# Altium schematic sheet dv_mcu.SchDoc(dv_mcu)
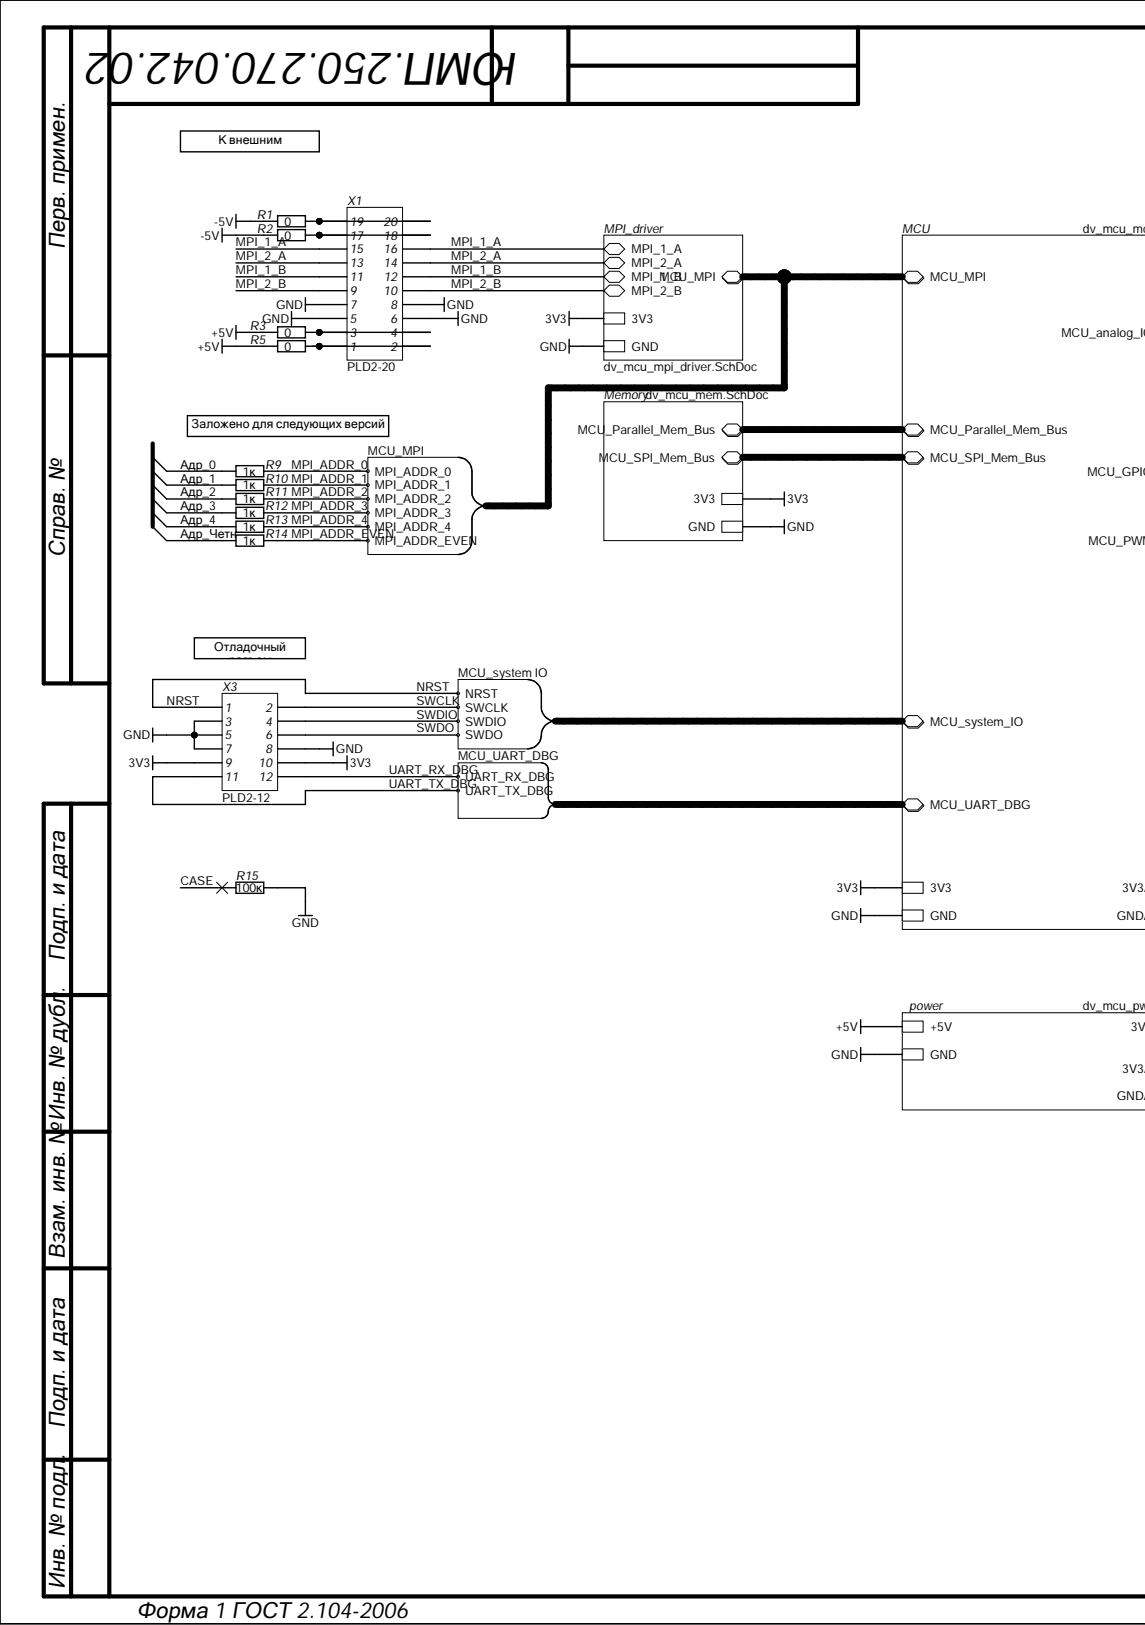
[diagram p0: dv_mcu.SchDoc, left]
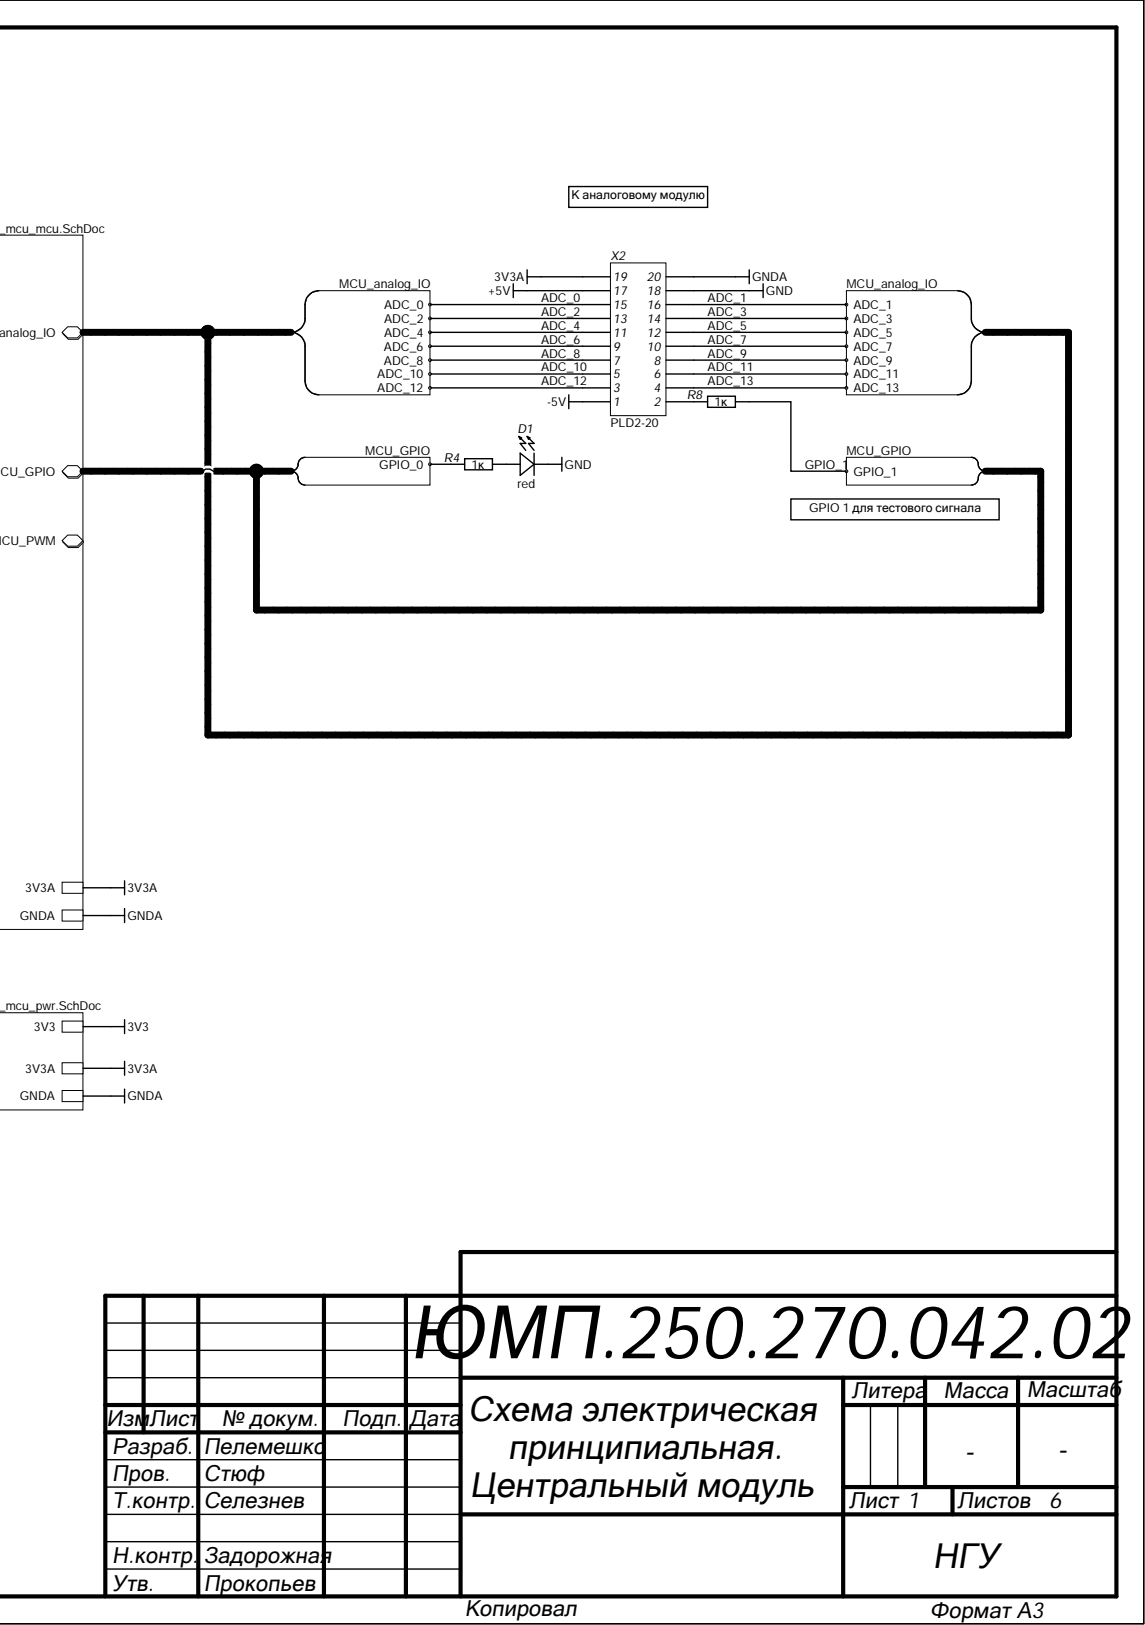
[diagram p1: dv_mcu.SchDoc, right]

## Parts
D1  value red  [LED-0805]  (hidden comment: LED)
R1  value 0  [SMD_0805]  (hidden comment: OSM R1-12)
R2  value 0  [SMD_0805]  (hidden comment: OSM R1-12)
R3  value 0  [SMD_0805]  (hidden comment: OSM R1-12)
R4  value 1к  [SMD_0805]  (hidden comment: OSM R1-12)
R5  value 0  [SMD_0805]  (hidden comment: OSM R1-12)
R8  value 1к  [SMD_0805]  (hidden comment: OSM R1-12)
R9  value 1к  [SMD_0805]  (hidden comment: OSM R1-12)
R10  value 1к  [SMD_0805]  (hidden comment: OSM R1-12)
R11  value 1к  [SMD_0805]  (hidden comment: OSM R1-12)
R12  value 1к  [SMD_0805]  (hidden comment: OSM R1-12)
R13  value 1к  [SMD_0805]  (hidden comment: OSM R1-12)
R14  value 1к  [SMD_0805]  (hidden comment: OSM R1-12)
R15  value 100к  [SMD_0805]  (hidden comment: OSM R1-12)
X1  Наименование: PLD2-20  [SD87340-20]  (hidden comment: Header 2X20)
X2  Наименование: PLD2-20  [SD87340-20]  (hidden comment: Header 2X20)
X3  Header 2X12  [PLD2-12]  (value not drawn)

## Nets
3V3: X3.9 X3.10
3V3A: X2.19
+5V: R3.1 R5.1 X2.17
-5V: R1.1 R2.1 X2.1
GND: D1.2 R15.2 X1.5 X1.6 X1.7 X1.8 X2.18 X3.3 X3.5 X3.7 X3.8
GNDA: X2.20
ADC_0: X2.15  [also labelled ADC_]
ADC_1: X2.16  [also labelled ADC_]
ADC_2: X2.13  [also labelled ADC_]
ADC_3: X2.14  [also labelled ADC_]
ADC_4: X2.11  [also labelled ADC_]
ADC_5: X2.12  [also labelled ADC_]
ADC_6: X2.9  [also labelled ADC_]
ADC_7: X2.10  [also labelled ADC_]
ADC_8: X2.7  [also labelled ADC_]
ADC_9: X2.8  [also labelled ADC_]
ADC_10: X2.5  [also labelled ADC_]
ADC_11: X2.6  [also labelled ADC_]
ADC_12: X2.3  [also labelled ADC_]
ADC_13: X2.4  [also labelled ADC_]
CASE: R15.1
GPIO_1: R8.2
MPI_1_A: X1.15 X1.16
MPI_1_B: X1.11 X1.12
MPI_2_A: X1.13 X1.14
MPI_2_B: X1.9 X1.10
MPI_ADDR_0: R9.2
MPI_ADDR_1: R10.2
MPI_ADDR_2: R11.2
MPI_ADDR_3: R12.2
MPI_ADDR_4: R13.2
MPI_ADDR_EVEN: R14.2
NRST: X3.1
SWCLK: X3.2
SWDIO: X3.4
SWDO: X3.6
UART_RX_DBG: X3.12
UART_TX_DBG: X3.11
Адр_0: R9.1
Адр_1: R10.1
Адр_2: R11.1
Адр_3: R12.1
Адр_4: R13.1
Адр_Четн: R14.1
NetD1_1: D1.1 R4.2
NetR1_2: R1.2 X1.19 X1.20
NetR2_2: R2.2 X1.17 X1.18
NetR3_2: R3.2 X1.3 X1.4
NetR4_1: R4.1
NetR5_2: R5.2 X1.1 X1.2
NetR8_1: R8.1 X2.2
MCU_analog_IO.ADC_REF:
MCU_GPIO.GPIO_2:
MCU_GPIO.GPIO_3:
MCU_GPIO.GPIO_4:
MCU_GPIO.GPIO_5:
MCU_GPIO.GPIO_6:
MCU_GPIO.GPIO_7:
MCU_GPIO.STM_0:
MCU_GPIO.STM_1:
MCU_GPIO.STM_2:
MCU_GPIO.STM_3:
MCU_GPIO.STM_4:
MCU_GPIO.STM_5:
MCU_GPIO.STM_6:
MCU_GPIO.STM_7:
MCU_GPIO.STM_8:
MCU_GPIO.STM_9:
MCU_MPI.MILA_RX_N:
MCU_MPI.MILA_RX_P:
MCU_MPI.MILA_TX_N:
MCU_MPI.MILA_TX_P:
MCU_MPI.MILB_RX_N:
MCU_MPI.MILB_RX_P:
MCU_MPI.MILB_TX_N:
MCU_MPI.MILB_TX_P:
MCU_Parallel_Mem_Bus.A_0:
MCU_Parallel_Mem_Bus.A_1:
MCU_Parallel_Mem_Bus.A_2:
MCU_Parallel_Mem_Bus.A_3:
MCU_Parallel_Mem_Bus.A_4:
MCU_Parallel_Mem_Bus.A_5:
MCU_Parallel_Mem_Bus.A_6:
MCU_Parallel_Mem_Bus.A_7:
MCU_Parallel_Mem_Bus.A_8:
MCU_Parallel_Mem_Bus.A_9:
MCU_Parallel_Mem_Bus.A_10:
MCU_Parallel_Mem_Bus.A_11:
MCU_Parallel_Mem_Bus.A_12:
MCU_Parallel_Mem_Bus.A_13:
MCU_Parallel_Mem_Bus.A_14:
MCU_Parallel_Mem_Bus.D_0:
MCU_Parallel_Mem_Bus.D_1:
MCU_Parallel_Mem_Bus.D_2:
MCU_Parallel_Mem_Bus.D_3:
MCU_Parallel_Mem_Bus.D_4:
MCU_Parallel_Mem_Bus.D_5:
MCU_Parallel_Mem_Bus.D_6:
MCU_Parallel_Mem_Bus.D_7:
MCU_Parallel_Mem_Bus.~CS_0:
MCU_Parallel_Mem_Bus.~CS_1:
MCU_Parallel_Mem_Bus.~OE:
MCU_Parallel_Mem_Bus.~WE:
MCU_PWM:
MCU_SPI_Mem_Bus.MISO:
MCU_SPI_Mem_Bus.MOSI:
MCU_SPI_Mem_Bus.SCK:
MCU_SPI_Mem_Bus.~FS_0:
MCU_SPI_Mem_Bus.~FS_1:
MCU_SPI_Mem_Bus.~FS_2:
MCU_SPI_Mem_Bus.~FS_3:
MCU_SPI_Mem_Bus.~FS_4:

## Library data of the parts
D1 = LED: Назначение: Светодиод; Наименование: SMD-LED; Потребление, Вт: 0,01; Component Kind: Standard; Library Name: Diod.IntLib
R1-R5 R8-R15 = Resistor: Назначение: SMD-резистор; Наименование: ОСМ Р1-12; Потребление, Вт: 0,001; Точность: %; Component Kind: Standard; Description: Resistor; Library Name: Passive.IntLib
X1 X2 = Header 2X20: Header, 20-Pin, Dual row; Потребление, Вт: 0,0; Component Kind: Standard; Library Name: Connector.IntLib; Назначение: Header, 20-Pin, Dual row
X3 = Header 2X12: Header, 12-Pin, Dual row; Потребление, Вт: 0,0; Component Kind: Standard; Library Name: Connector.IntLib; Назначение: Header, 12-Pin, Dual row; Наименование: PLD2-12
